# Altium schematic sheet Pi Sheet.SchDoc
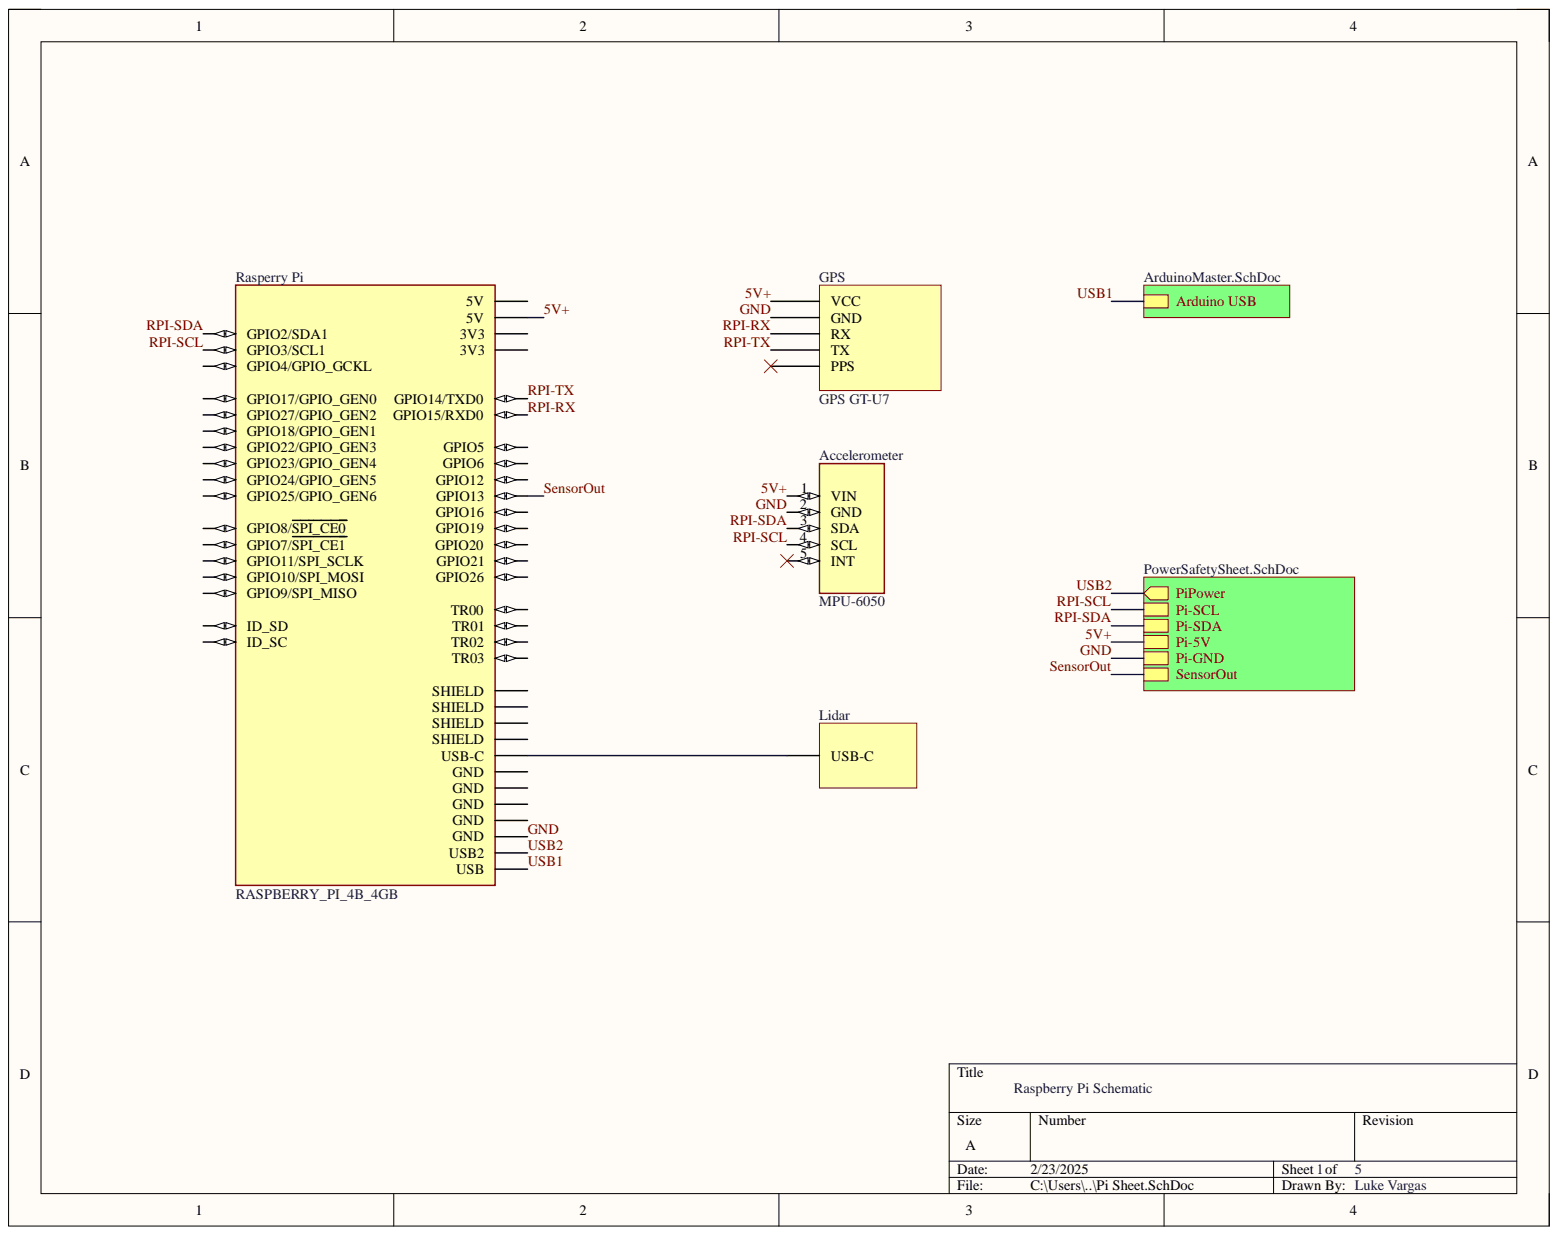
[diagram p0: Pi Sheet.SchDoc]

## Parts
Accelerometer  MPU-6050  [SEN0142]
GPS  GPS GT-U7
Lidar  Lidar
Rasperry_Pi  RASPBERRY_PI_4B_4GB  [MODULE_RASPBERRY_PI_4B_4GB]

## Nets
5V+: Accelerometer.1(VIN) GPS.VCC Rasperry_Pi.4(5V)
GND: Accelerometer.2(GND) GPS.GND Rasperry_Pi.30(GND)
RPI-RX: GPS.RX Rasperry_Pi.10(GPIO15/RXD0)
RPI-SCL: Accelerometer.4(SCL) Rasperry_Pi.5(GPIO3/SCL1)
RPI-SDA: Accelerometer.3(SDA) Rasperry_Pi.3(GPIO2/SDA1)
RPI-TX: GPS.TX Rasperry_Pi.8(GPIO14/TXD0)
SensorOut: Rasperry_Pi.33(GPIO13)
USB1: Rasperry_Pi.USB
USB2: Rasperry_Pi.USB2
NetLidar_USB: Lidar.USB Rasperry_Pi.USB-C

Not connected: Accelerometer 5(INT); GPS PPS; Rasperry_Pi 1(3V3) 2(5V) 7(GPIO4/GPIO_GCKL) 9(GND) 11(GPIO17/GPIO_GEN0) 12(GPIO18/GPIO_GEN1) 13(GPIO27/GPIO_GEN2) 14(GND) 15(GPIO22/GPIO_GEN3) 16(GPIO23/GPIO_GEN4) 17(3V3) 18(GPIO24/GPIO_GEN5) 19(GPIO10/SPI_MOSI) 20(GND) 21(GPIO9/SPI_MISO) 22(GPIO25/GPIO_GEN6) 23(GPIO11/SPI_SCLK) 24(GPIO8/SPI_CE0) 25(GND) 26(GPIO7/SPI_CE1) 27(ID_SD) 28(ID_SC) 29(GPIO5) 31(GPIO6) 32(GPIO12) 35(GPIO19) 36(GPIO16) 37(GPIO26) 38(GPIO20) 40(GPIO21) 41(TR01) 42(TR00) 43(TR03) 44(TR02) S1(SHIELD) S2(SHIELD) S3(SHIELD) S4(SHIELD)

## Library data of the parts
Accelerometer = CMP-015-000000-1: MPU-6050 series Accelerometer, Gyroscope, 3 Axis Sensor Evaluation Board; MF: DFRobot; Check_prices: https://www.snapeda.com/parts/SEN0142/DFRobot/view-part/?ref=eda; Component Kind: Standard; Component Type: Standard; Design Item ID: SEN0142; MP: SEN0142; Package: None; Pin Count: 5; SnapEDA_Link: https://www.snapeda.com/parts/SEN0142/DFRobot/view-part/?ref=snap; Source: SEN0142.SchLib; Library Name: Thousand Sunny
Rasperry_Pi = CMP-007-000000-1: BCM2711 Raspberry Pi 4 Model B 4GB - ARMÂ® CortexÂ®-A72 MPU Embedded Evaluation Board; MP: RASPBERRY PI 4B/4GB; Availability: In Stock; Check_prices: https://www.snapeda.com/parts/RASPBERRY%20PI%204B/4GB/Raspberry+Pi/view-part/?ref=eda; Component Kind: Standard; Component Type: Standard; Design Item ID: RASPBERRY_PI_4B_4GB; MF: Raspberry Pi; Package: None; Pin Count: 48; Price: None; Purchase-URL: https://pricing.snapeda.com/search/part/RASPBERRY PI 4B/4GB/?ref=eda; SnapEDA_Link: https://www.snapeda.com/parts/RASPBERRY%20PI%204B/4GB/Raspberry+Pi/view-part/?ref=snap; Source: RASPBERRY_PI_4B_4GB.SchLib; Library Name: Dalena Nguyen
GPS = GPS GT-U7: Component Kind: Standard; Library Name: RushbotWiring.SCHLIB
Lidar = Lidar: Component Kind: Standard; Library Name: RushbotWiring.SCHLIB
